# Altium schematic sheet [11] Ethernet.SchDoc("[11] Ethernet")
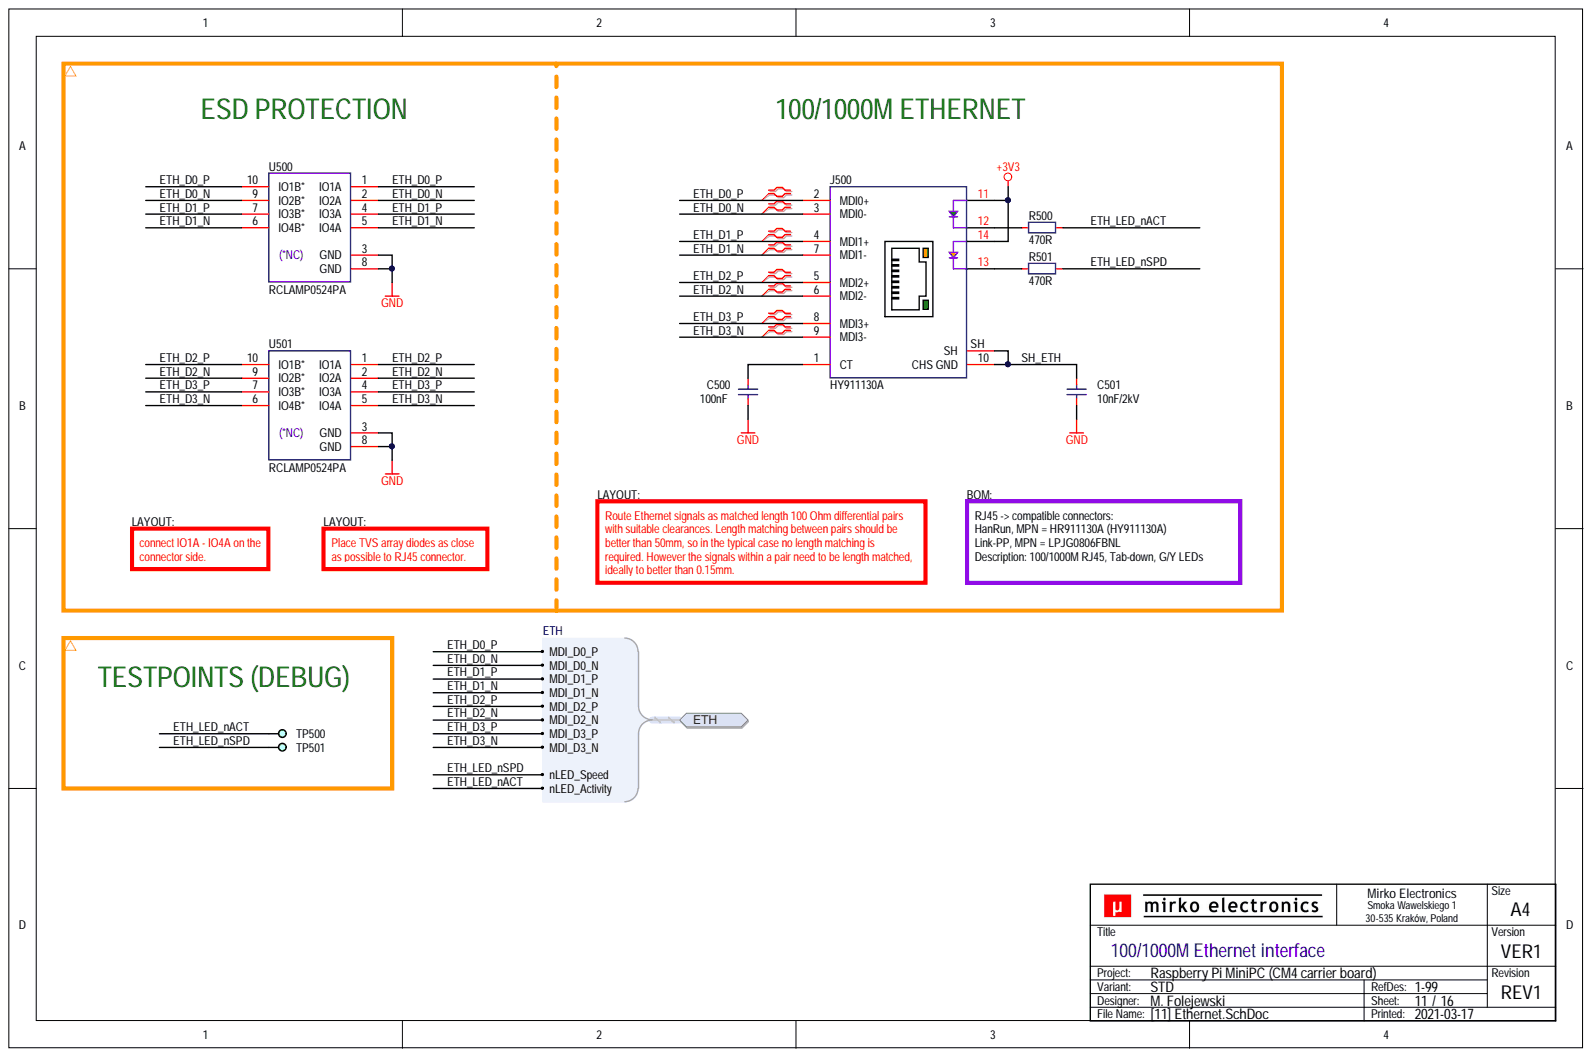
[diagram p0: [11] Ethernet.SchDoc]

## Parts
C500  100nF  [CAP_0603_R]
C501  10nF/2kV  [CAP_1812_R]
J500  HY911130A
R500  470R  [RES_0603_R]
R501  470R  [RES_0603_R]
TP500  DEBUG  [PCB_TP_DBG_50]  (value not drawn)  (no BOM)
TP501  DEBUG  [PCB_TP_DBG_50]  (value not drawn)  (no BOM)
U500  RCLAMP0524PA  [ICC_SLP2510P8_250X100X60_P50_R]
U501  RCLAMP0524PA  [ICC_SLP2510P8_250X100X60_P50_R]

## Ports
ETH, ETH.MDI_D0_N, ETH.MDI_D0_P, ETH.MDI_D1_N, ETH.MDI_D1_P, ETH.MDI_D2_N, ETH.MDI_D2_P, ETH.MDI_D3_N, ETH.MDI_D3_P, ETH.nLED_Activity, ETH.nLED_Speed

## Nets
+3V3: J500.11 J500.14
GND: C500.1 C501.1 U500.3(GND) U500.8(GND) U501.3(GND) U501.8(GND)
ETH_D0_N: J500.3(MDI0-) U500.2(IO2A) U500.9(IO2B*) ; port ETH
ETH_D0_P: J500.2(MDI0+) U500.1(IO1A) U500.10(IO1B*) ; port ETH
ETH_D1_N: J500.7(MDI1-) U500.5(IO4A) U500.6(IO4B*) ; port ETH
ETH_D1_P: J500.4(MDI1+) U500.4(IO3A) U500.7(IO3B*) ; port ETH
ETH_D2_N: J500.6(MDI2-) U501.2(IO2A) U501.9(IO2B*) ; port ETH
ETH_D2_P: J500.5(MDI2+) U501.1(IO1A) U501.10(IO1B*) ; port ETH
ETH_D3_N: J500.9(MDI3-) U501.5(IO4A) U501.6(IO4B*) ; port ETH
ETH_D3_P: J500.8(MDI3+) U501.4(IO3A) U501.7(IO3B*) ; port ETH
ETH_LED_nACT: R500.1 TP500.1 ; port ETH
ETH_LED_nSPD: R501.1 TP501.1 ; port ETH
SH_ETH: C501.2 J500.10(CHS_GND) J500.SH
NetC500_2: C500.2 J500.1(CT)
NetJ500_12: J500.12 R500.2
NetJ500_13: J500.13 R501.2

## Library data of the parts
C500 = CAP-0008: Capacitor; MLCC; 100nF; TBD; TBD; TBD; TBD; SMD; 0603 (1608);; Database Table Name: CAPACITOR$; Voltage Rating: TBD; Value: 100nF; Tolerance: TBD; ROHS Status: TBD; Packaging Type: TBD; Package (Case): 0603 (1608); Operating Temperature: TBD; MPN: TBD; Mounting Type: SMD; Manufacturer: TBD; ID: CAP-0008; Features: TBD; Dielectric: TBD; Component Type: Capacitor; Component Subtype: MLCC; Component Kind: Standard; Component Height: TBD; Component Body: TBD; Capacitance: 100nF; Library Name: MirFol_db_local.DbLib
C501 = CAP-0027: Capacitor; MLCC; GENERIC; TBD; TBD; TBD; TBD; SMD; 1812 (4532);; Database Table Name: CAPACITOR$; Component Body: ???4.50 x 3.20?mm; Voltage Rating: TBD; Value: 10nF/2kV; Tolerance: TBD; ROHS Status: TBD; Packaging Type: TBD; Package (Case): 1812 (4532); Operating Temperature: TBD; MPN: GENERIC; Mounting Type: SMD; Manufacturer: GENERIC; ID: CAP-0027; Features: TBD; Dielectric: TBD; Component Type: Capacitor; Component Subtype: MLCC; Component Kind: Standard; Component Height: TBD; Capacitance: GENERIC; Library Name: MirFol_db_local.DbLib
J500 = CON_HAN_HY911130A: Connector; RJ45;; Manufacturer: HanRun; Value: HY911130A; MPN: HY911130A; Component Kind: Standard; Library Name: MirFol_lib.SchLib
R500 R501 = RES-0042: Resistor; Thick Film; 470R; 1%; 100mW; 100PPM; 75V; Standard; SMD; 0603 (1608);; MPN: TBD; Manufacturer: Yageo Corporation; Packaging Type: Tape & Reel; Database Table Name: RESISTOR$; Component Body: 1.60 x 0.80mm; Voltage Rating: 75V; Value: 470R; Tolerance: 1%; TCR: 100PPM; ROHS Status: RoHS Compliant; Resistance: 470R; Power Rating: 100mW; Package (Case): 0603 (1608); Operating Temperature: -55°C to +155°C; Mounting Type: SMD; ID: RES-0042; Features: Standard; Component Type: Resistor; Component Subtype: Thick Film; Component Kind: Standard; Component Height: 0.45mm; Library Name: MirFol_db_local.DbLib
TP500 TP501 = PCB_TP_DBG: PCB Marking; Test Point (Debug);; Value: DEBUG; Library Name: MirFol_lib.SchLib
U500 U501 = ICC-0005: IC; TVS Arrays; ESD Protection; 4-Channel; 17kV Air; 12kV Contact; 0.3pF Capitance; TBD; SMD; TBD;; MPN: RCLAMP0524PATCT; Manufacturer: Semtech Corporation; Pin Pitch: 0.50mm; Packaging Type: Tape & Reel; Database Table Name: IC (MISC)$; Value: RCLAMP0524PA; ROHS Status: RoHS Compliant; Power Supply: TBD; Pin Count: 10; Package: TBD; Operating Temperature: -55°C to +125°C; Mounting Type: SMD; ID: ICC-0005; Features: ESD Protection; 4-Channel; 17kV Air; 12kV Contact; 0.3pF Capitance;; Component Type: IC; Component Subtype: TVS Arrays; Component Kind: Standard; Component Height: TBD; Component Body: TBD; Library Name: MirFol_db_local.DbLib
